# Altium schematic sheet MIPI_2.SchDoc(MIPI_2)
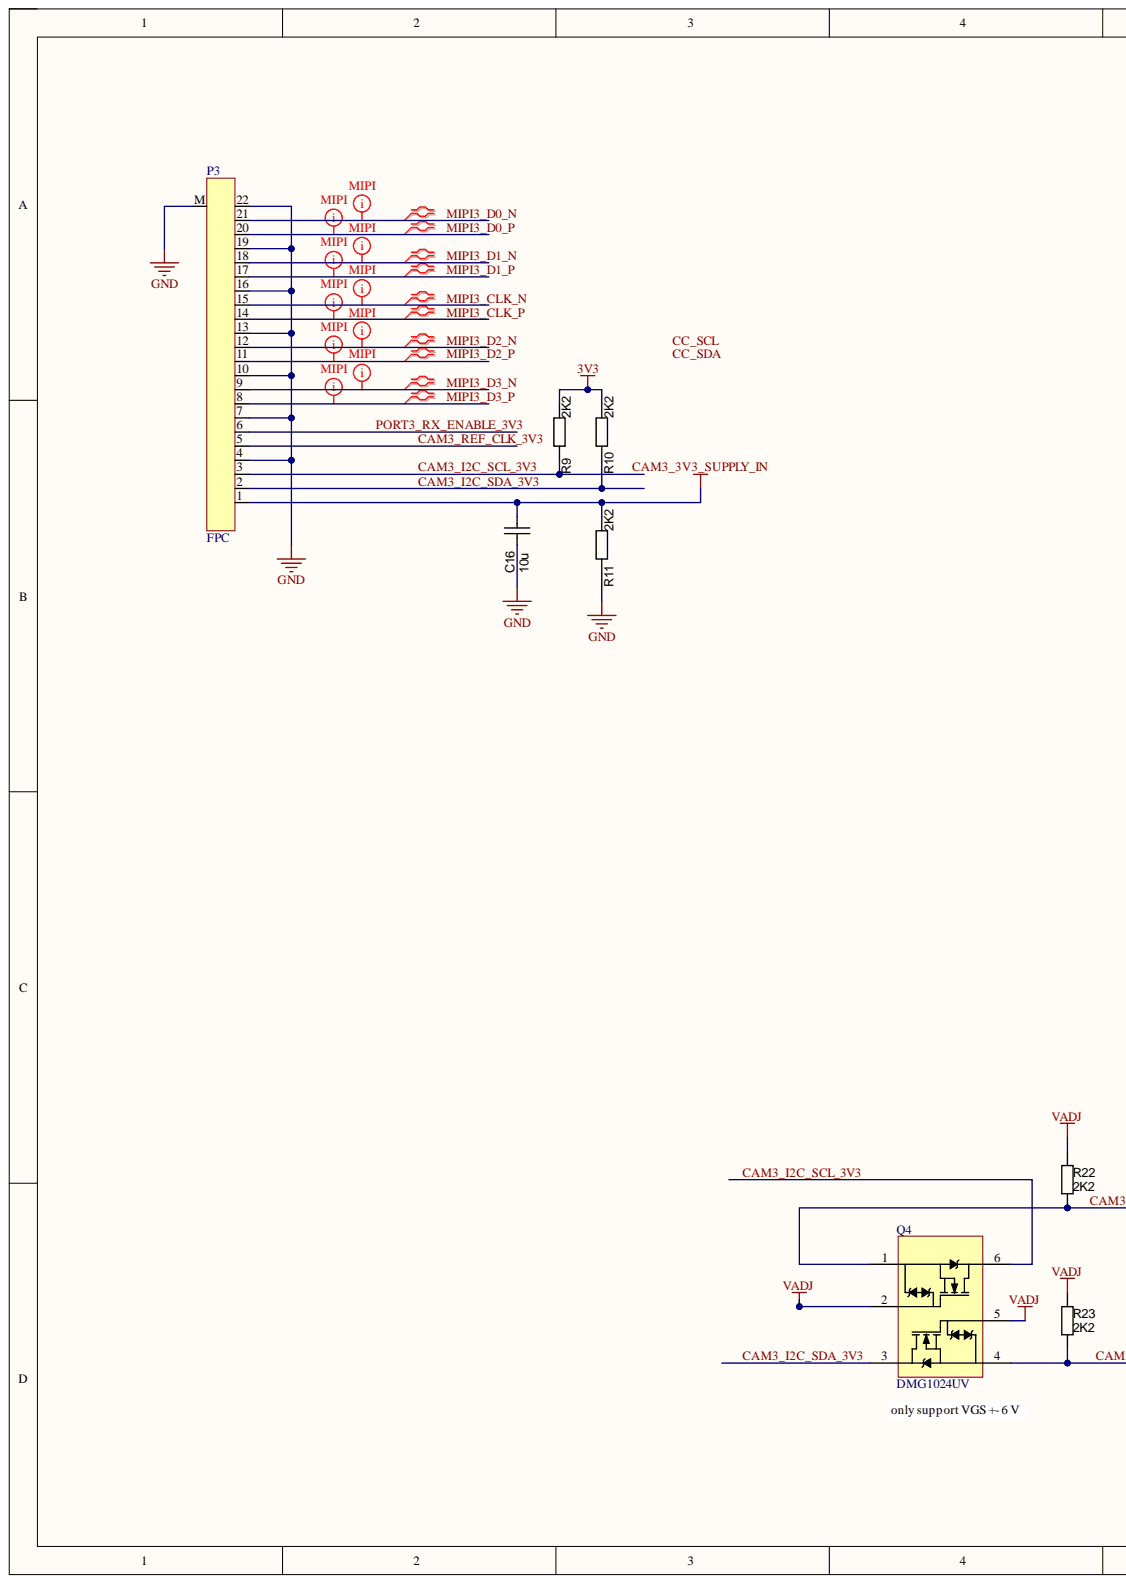
[diagram p0: MIPI_2.SchDoc, left]
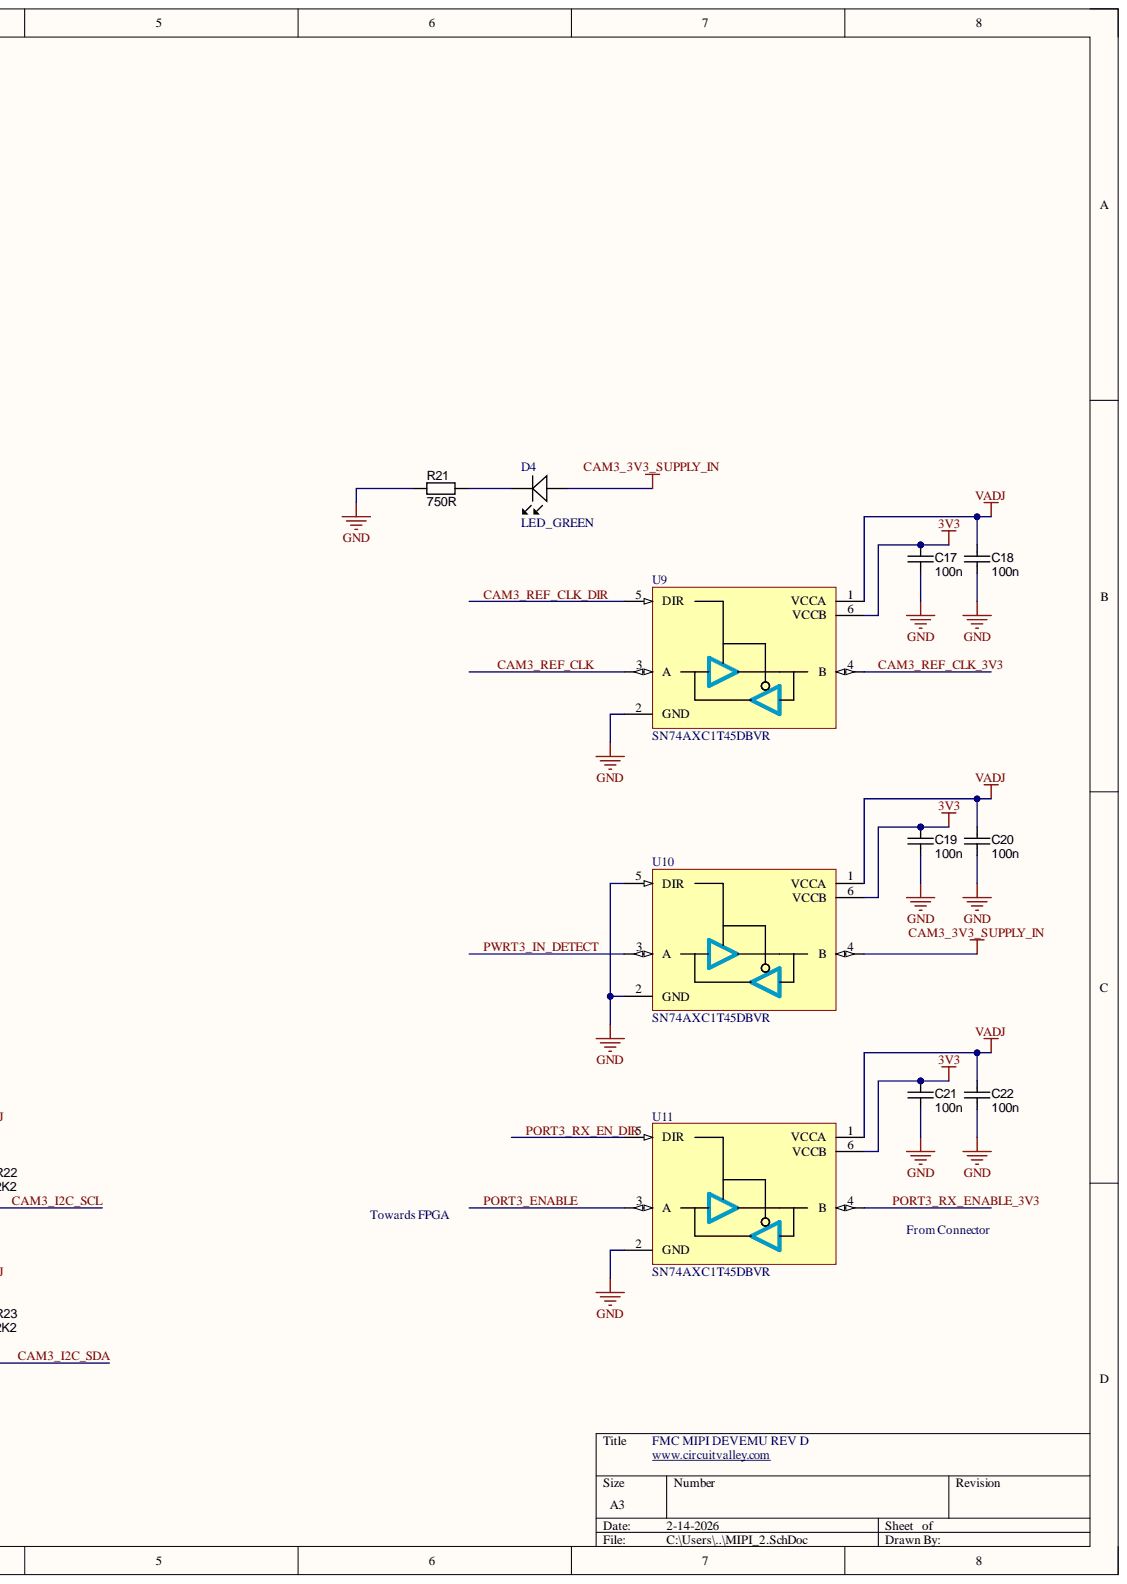
[diagram p1: MIPI_2.SchDoc, right]

## Parts
C16  value 10u  [CAPC1608N]  (hidden comment: approved sub OK)
C17  100n  [CAPC1005N]
C18  100n  [CAPC1005N]
C19  100n  [CAPC1005N]
C20  100n  [CAPC1005N]
C21  100n  [CAPC1005N]
C22  100n  [CAPC1005N]
D4  LED_GREEN  [1206_LED]
P3  FPC  [AFC11-S22ICA-00]
Q4  DMG1024UV  [SOT563]
R9  value 2K2  [RESC1005N]  (hidden comment: Thick Film)
R10  value 2K2  [RESC1005N]  (hidden comment: Thick Film)
R11  value 2K2  [RESC1005N]  (hidden comment: Thick Film)
R21  value 750R  [RESC1608N]  (hidden comment: RES_NL_0603)
R22  value 2K2  [RESC1005N]  (hidden comment: Thick Film)
R23  value 2K2  [RESC1005N]  (hidden comment: Thick Film)
U9  SN74AXC1T45DBVR  [SOT23-6]
U10  SN74AXC1T45DBVR  [SOT23-6]
U11  SN74AXC1T45DBVR  [SOT23-6]

## Nets
3V3: C17.2 C19.2 C21.2 R9.1 R10.1 U9.6(VCCB) U10.6(VCCB) U11.6(VCCB)
CAM3_3V3_SUPPLY_IN: C16.1 D4.A P3.1 R11.1 U10.4(B)
GND: C16.2 C17.1 C18.1 C19.1 C20.1 C21.1 C22.1 P3.4 P3.7 P3.10 P3.13 P3.16 P3.19 P3.22 P3.M R11.2 R21.1 U9.2(GND) U10.2(GND) U10.5(DIR) U11.2(GND)
VADJ: C18.2 C20.2 C22.2 Q4.2 Q4.5 R22.2 R23.2 U9.1(VCCA) U10.1(VCCA) U11.1(VCCA)
CAM3_I2C_SCL: Q4.1 R22.1
CAM3_I2C_SCL_3V3: P3.3 Q4.6 R9.2
CAM3_I2C_SDA: Q4.4 R23.1
CAM3_I2C_SDA_3V3: P3.2 Q4.3 R10.2
CAM3_REF_CLK: U9.3(A)
CAM3_REF_CLK_3V3: P3.5 U9.4(B)
CAM3_REF_CLK_DIR: U9.5(DIR)
MIPI3_CLK_N: P3.15
MIPI3_CLK_P: P3.14
MIPI3_D0_N: P3.21
MIPI3_D0_P: P3.20
MIPI3_D1_N: P3.18
MIPI3_D1_P: P3.17
MIPI3_D2_N: P3.12
MIPI3_D2_P: P3.11
MIPI3_D3_N: P3.9
MIPI3_D3_P: P3.8
PORT3_ENABLE: U11.3(A)
PORT3_RX_EN_DIR: U11.5(DIR)
PORT3_RX_ENABLE_3V3: P3.6 U11.4(B)
PWRT3_IN_DETECT: U10.3(A)
NetD4_K: D4.K R21.2
CC_SCL:
CC_SDA:

## Library data of the parts
C16 = CAPC_560p_0603_5%: Capacitor SMD Cer 560pF 5% 50V NP0 0603; Manufacturer 1: AVX Corporation; Manufacturer Part Number 1: 06035A561JAT2A; Capacitance: 470pF; Material: ceramic; Ratings: 50V; NP0; Applications: General Purpose; Component Kind: Standard; ComponentLink1Description: http://www.avx.com/docs/Catalogs/ccog.pdf; ComponentLink1URL: http://www.avx.com/docs/Catalogs/ccog.pdf; Height - Seated (Max): -; Lead Spacing: -; Lead Style: -; Library Name: PBL - Capacitors.SCHLIB; Mounting Type: Surface Mount, MLCC; Operating Temperature: -55°C ~ 125°C; Package / Case: 0603 (1608 metric); Size / Dimension: 0.063" L x 0.032" W (1.60mm x 0.81mm); Supplier 1: Digi-Key; Supplier Part Number 1: 478-1184-1-ND; Thickness (Max): 0.035" (0.90mm); Voltage - Rated: 50V
C17-C22 = CAPC_10n_0402_16V_10%: Capacitor SMD Cer 10nF 10% 16V X7R 0402; Manufacturer 1: AVX Corporation; Manufacturer Part Number 1: 0402YC103KAT2A; Ratings: 16V; X7R; Component Kind: Standard; ComponentLink1Description: http://www.avx.com/docs/Catalogs/cx7r.pdf; ComponentLink1URL: http://www.avx.com/docs/Catalogs/cx7r.pdf; ComponentLink2Description: http://www.yageo.com/documents/recent/UPY-GPHC_X7R_6.3V-to-50V_8.pdf; ComponentLink2URL: http://www.yageo.com/documents/recent/UPY-GPHC_X7R_6.3V-to-50V_8.pdf; Library Name: PBL - Capacitors.SCHLIB; Manufacturer 2: Yageo; Manufacturer Part Number 2: CC0402KRX7R7BB103; Operating Temperature: -55°C ~ 125°C; Package / Case: 0402 (1005 Metric); Size / Dimension: 0.039" L x 0.020" W (1.00mm x 0.50mm); Supplier 1: Digi-Key; Supplier 2: Digi-Key; Supplier Part Number 1: 478-1114-1-ND; Supplier Part Number 2: 311-1042-1-ND; Tolerance: ±10%; Value: 100n
D4 = LED: Component Kind: Standard; Description: LED; Library Name: cvlib.SchLib
P3 = FPC22_PIN: FPC 22 Pin; Component Kind: Standard; Library Name: cvlib.SchLib
R9-R11 R22 R23 = RES_3K3_0402_5%: Resistor SMD chip 3.3k Ohm 0.063W 5% 0402; Manufacturer 1: Yageo; Manufacturer Part Number 1: RC0402JR-073K3L; Ratings: 1/16 W; Component Kind: Standard; ComponentLink1Description: http://www.yageo.com/documents/recent/PYu-RC0402_51_RoHS_L_6.pdf; ComponentLink1URL: http://www.yageo.com/documents/recent/PYu-RC0402_51_RoHS_L_6.pdf; Library Name: PBL - Resistors.SCHLIB; Material: Thick Film; Package / Case: 0402 (1005 metric); Supplier 1: Digi-Key; Supplier Part Number 1: 311-3.3KJRCT-ND; Tolerance: ±5%
R21 = RES_NL_0603: Resistor, SMD chip; Manufacturer 1: Royal Ohm; Ratings: 1/10 W; Tolerance: ±5%; Component Kind: Standard; Library Name: PBL - Resistors.SCHLIB; Package / Case: 0603 (1608 metric)
U9-U11 = SN74LVC1T45DBVR: Single Bit Level Translation; Component Kind: Standard; Library Name: cvlib.SchLib
Q4 = DMG1024UV: Component Kind: Standard; Description: DMG1024UV; Library Name: cvlib.SchLib
